# Altium schematic sheet Power.SchDoc
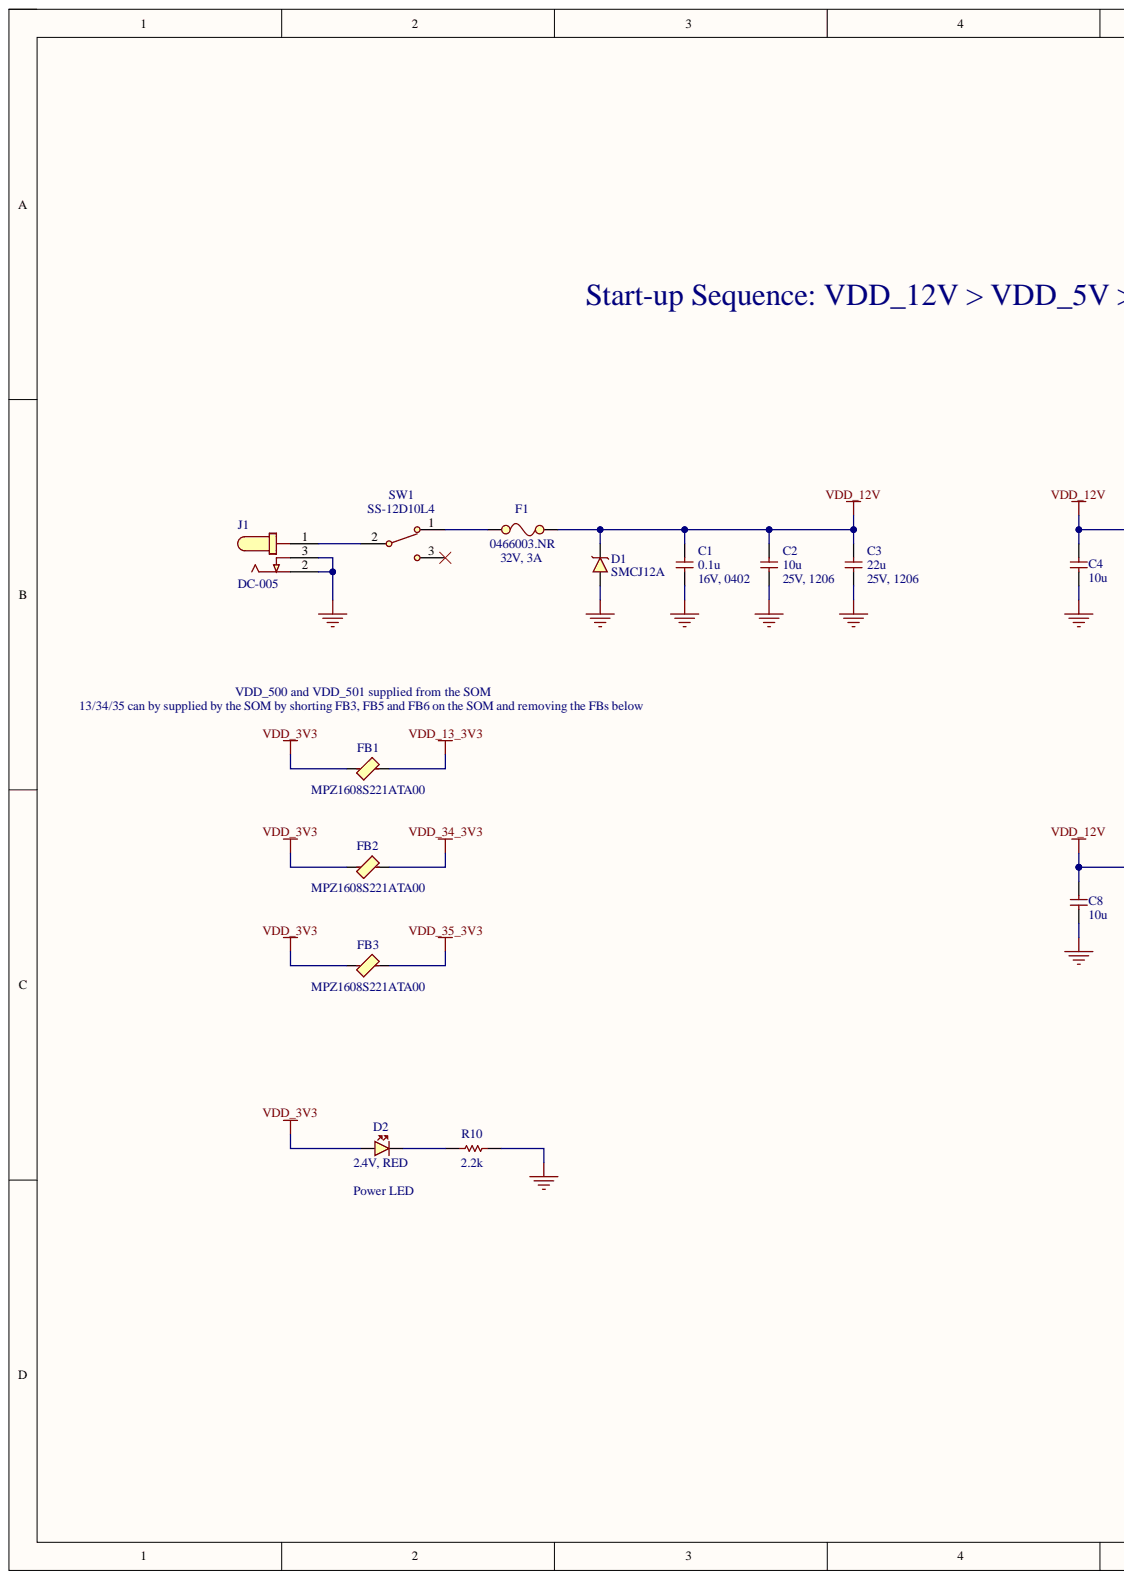
[diagram p0: Power.SchDoc, left]
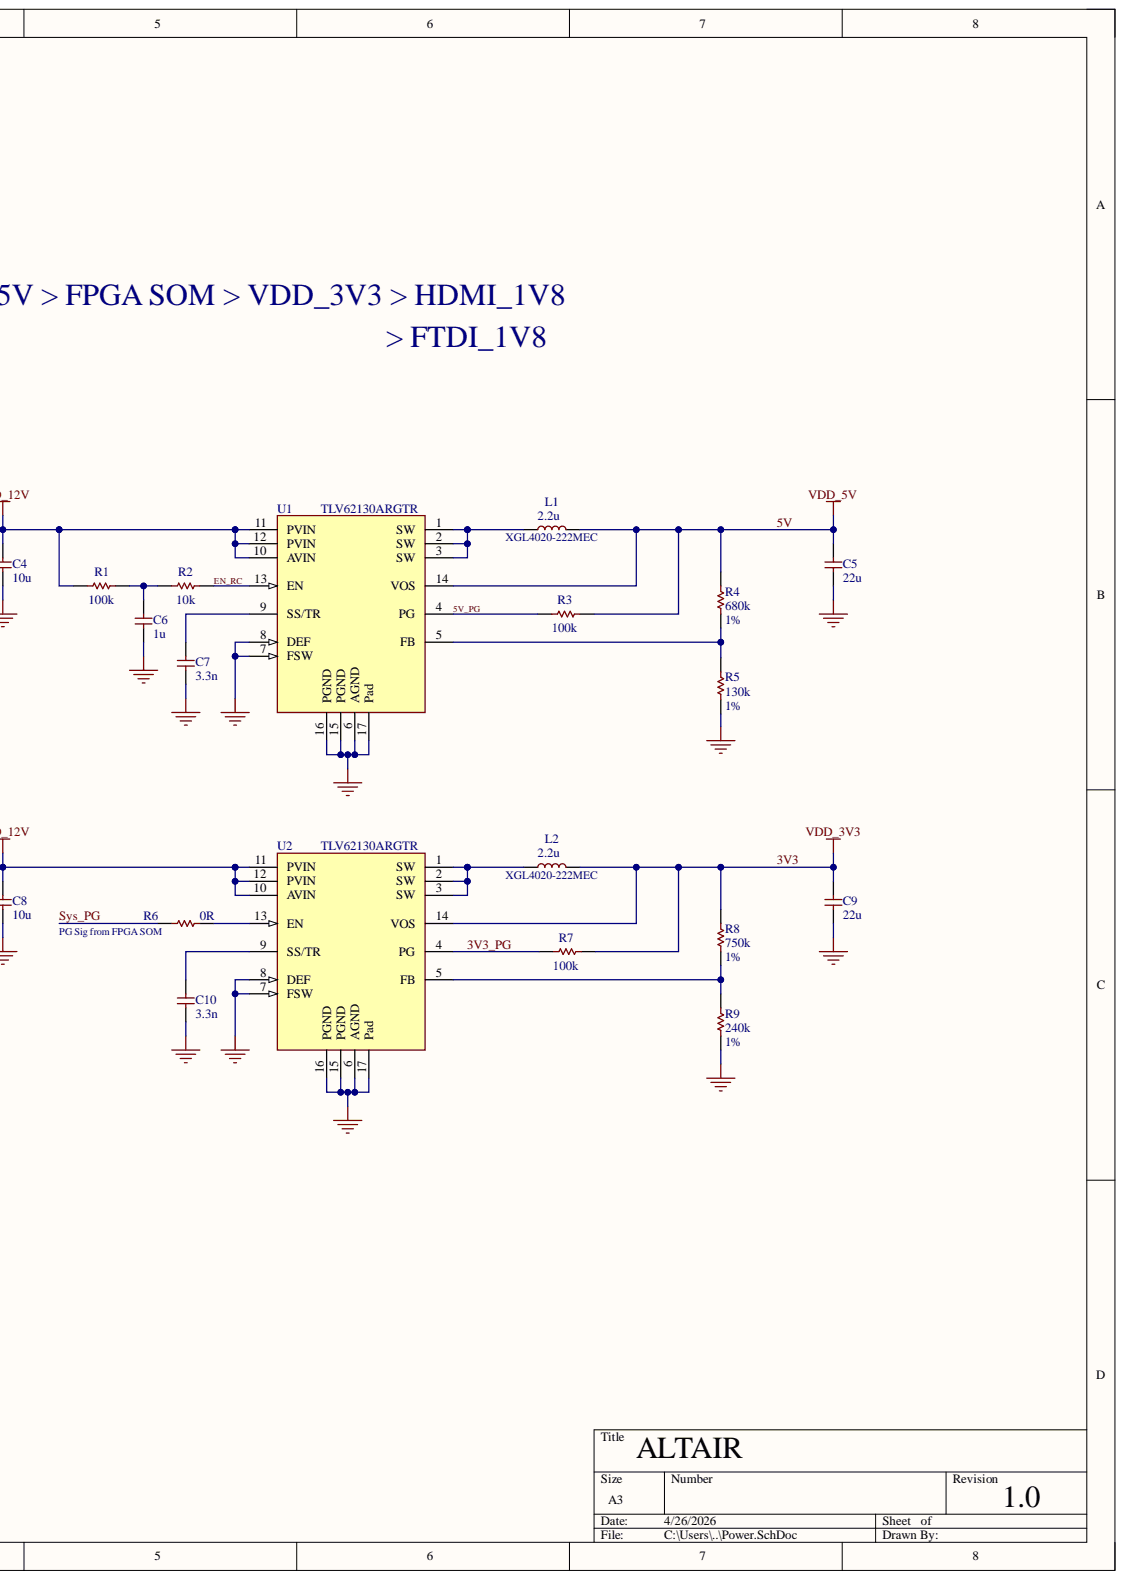
[diagram p1: Power.SchDoc, right]

## Parts
C1  0.1u  [CAP_0402]
C2  10u  [CAP_1206]
C3  22u  Footprint JLCPCB: 1206  Note/Misc: 25V, 1206  [CAP_1206]
C4  10u  [CAP_1206]
C5  22u  [CAP_1206]
C6  1u  [CAP_0402]
C7  3.3n  [CAP_0402]
C8  10u  [CAP_1206]
C9  22u  [CAP_1206]
C10  3.3n  [CAP_0402]
D1  SMCJ12A  [LFUS-DO-214AB-2_V]
D2  RED  Note/Misc: 2.4V, RED  [LED_0805_RED]
F1  0466003.NR  Note/Misc: 32V, 3A  [0466003.NR]
FB1  220  [BEADC1608X75N]  (value not drawn)
FB2  220  [BEADC1608X75N]  (value not drawn)
FB3  220  [BEADC1608X75N]  (value not drawn)
J1  DC-005  [DC-005-A200]
L1  2.2u  Note/Misc: XGL4020-222MEC  [XGL4020222MEC]
L2  2.2u  Note/Misc: XGL4020-222MEC  [XGL4020222MEC]
R1  100k  [RES_0402]
R2  10k  [RES_0402]
R3  100k  [RES_0402]
R4  680k  Note/Misc: 1%  [RES_0402]
R5  130k  Note/Misc: 1%  [RES_0402]
R6  0R  [RES_0402]
R7  100k  [RES_0402]
R8  750k  Note/Misc: 1%  [RES_0402]
R9  240k  Note/Misc: 1%  [RES_0402]
R10  2.2k  [RES_0402]
SW1  SS-12D10L4  [SS-12D10L5]
U1  TLV62130ARGTR  [FP-RGT0016C-MFG]
U2  TLV62130ARGTR  [FP-RGT0016C-MFG]

## Nets
GND: C1.1 C2.1 C3.1 C4.1 C5.1 C6.1 C7.1 C8.1 C9.1 C10.1 D1.2 J1.2 J1.3 R5.2 R9.2 R10.2 U1.6(AGND) U1.7(FSW) U1.8(DEF) U1.15(PGND) U1.16(PGND) U1.17(Pad) U2.6(AGND) U2.7(FSW) U2.8(DEF) U2.15(PGND) U2.16(PGND) U2.17(Pad)
VDD_12V: C1.2 C2.2 C3.2 C4.2 C8.2 D1.1 F1.2 R1.2 U1.10(AVIN) U1.11(PVIN) U1.12(PVIN) U2.10(AVIN) U2.11(PVIN) U2.12(PVIN)
VDD_13_3V3: FB1.2
VDD_34_3V3: FB2.2
VDD_35_3V3: FB3.2
3V3: C9.2 D2.A FB1.1 FB2.1 FB3.1 L2.2 R7.2 R8.2 U2.14(VOS)
3V3_PG: R7.1 U2.4(PG)
5V: C5.2 L1.2 R3.2 R4.2 U1.14(VOS)
5V_PG: R3.1 U1.4(PG)
EN_RC: R2.1 U1.13(EN)
Sys_PG: R6.1
NetC6_2: C6.2 R1.1 R2.2
NetC7_2: C7.2 U1.9(SS/TR)
NetC10_2: C10.2 U2.9(SS/TR)
NetD2_K: D2.K R10.1
NetF1_1: F1.1 SW1.1
NetJ1_1: J1.1 SW1.2
NetL1_1: L1.1 U1.1(SW) U1.2(SW) U1.3(SW)
NetL2_1: L2.1 U2.1(SW) U2.2(SW) U2.3(SW)
NetR4_1: R4.1 R5.1 U1.5(FB)
NetR6_2: R6.2 U2.13(EN)
NetR8_1: R8.1 R9.1 U2.5(FB)

Not connected: SW1 3

## Library data of the parts
C1 = CAP_0402: Capacitor SMD 0402; Part No: CL05B104KO5NNNC; Note/Misc: 16V, 0402; Component Kind: Standard; Footprint JLCPCB: 0402; JLCPCB Part #: C338112; Library Name: personalGenericLib.SchLib
C2 C4 C8 = CAP_1206: Capacitor SMD 1206; Part No: CL31A106KAHNNNE; Note/Misc: 25V, 1206; Component Kind: Standard; Footprint JLCPCB: 1206; JLCPCB Part #: C9807; Library Name: personalGenericLib.SchLib
C3 = CAP_1206: Capacitor SMD 1206; Part No: CL31A226KAHNNNE; Component Kind: Standard; JLCPCB Part #: C12891; Library Name: personalGenericLib.SchLib
C5 C9 = CAP_1206: Capacitor SMD 1206; Part No: CL31A226KAHNNNE; Note/Misc: 25V, 1206; Component Kind: Standard; Footprint JLCPCB: 1206; JLCPCB Part #: C12891; Library Name: personalGenericLib.SchLib
C6 = CAP_0402: Capacitor SMD 0402; Part No: CL05A105KP5NNNC; Note/Misc: 10V; Component Kind: Standard; Footprint JLCPCB: 0402; JLCPCB Part #: C14445; Library Name: personalGenericLib.SchLib
C7 C10 = CAP_0402: Capacitor SMD 0402; Part No: CC0402JRX7R8BB332; Component Kind: Standard; Footprint JLCPCB: 0402; JLCPCB Part #: C527004; Library Name: personalGenericLib.SchLib
D1 = SMCJ12A: Transient Voltage Suppression Diode, 12 V, -65 to 150 degC, 2-Pin DO-214AB (SMCJ), RoHS, Tape and Reel; Component Kind: Standard; Footprint JLCPCB: DO-214AB(SMCJ); JLCPCB Part #: C224038; Library Name: personalGenericLib.SchLib; Part No: SMCJ12A
D2 = LED_0805_RED: LED 0805 RED SMD; Part No: HL-PSC-2012S9AC; Component Kind: Standard; Footprint JLCPCB: 0805; JLCPCB Part #: C497952; Library Name: personalGenericLib.SchLib
F1 = 0466003.NR: FUSE MNT 3A 32VAC/VDC 1206; Component Kind: Standard; Footprint JLCPCB: 1206; JLCPCB Part #: C14165; Library Name: personalGenericLib.SchLib; Part No: 0466003.NR
FB1-FB3 = MPZ1608S221ATA00: Ferrite Bead; Component Kind: Standard; Footprint JLCPCB: 0603; JLCPCB Part #: C76815; Library Name: personalGenericLib.SchLib; Part No: MPZ1608S221ATA00
J1 = DC-005: DC Jack; Component Kind: Standard; Footprint JLCPCB: Plugin; JLCPCB Part #: C720557; Library Name: personalGenericLib.SchLib; Part No: DC-005
L1 L2 = IND_XGL4020-222MEC: Inductor SMD 2.2 uH; Component Kind: Standard; Footprint JLCPCB: 1616; JLCPCB Part #: C6012410; Library Name: personalGenericLib.SchLib; Part No: XGL4020-222MEC
R1 R3 R7 = RES_0402: Resistor SMD 0402; Part No: RC0402JR-07100KL; Component Kind: Standard; Footprint JLCPCB: 0402; JLCPCB Part #: C106234; Library Name: personalGenericLib.SchLib
R2 = RES_0402: Resistor SMD 0402; Part No: RC0402JR-0710KL; Component Kind: Standard; Footprint JLCPCB: 0402; JLCPCB Part #: C60489; Library Name: personalGenericLib.SchLib
R4 = RES_0402: Resistor SMD 0402; Part No: RC0402FR-07680KL; Component Kind: Standard; Footprint JLCPCB: 0402; JLCPCB Part #: C163456; Library Name: personalGenericLib.SchLib
R5 = RES_0402: Resistor SMD 0402; Part No: RC0402FR-07130KL; Component Kind: Standard; Footprint JLCPCB: 0402; JLCPCB Part #: C93946; Library Name: personalGenericLib.SchLib
R6 = RES_0402: Resistor SMD 0402; Part No: RC0402JR-070RL; Component Kind: Standard; Footprint JLCPCB: 0402; JLCPCB Part #: C60485; Library Name: personalGenericLib.SchLib
R8 = RES_0402: Resistor SMD 0402; Part No: RC0402FR-07750KL; Component Kind: Standard; Footprint JLCPCB: 0402; JLCPCB Part #: C137937; Library Name: personalGenericLib.SchLib
R9 = RES_0402: Resistor SMD 0402; Part No: RC0402FR-07240KL; Component Kind: Standard; Footprint JLCPCB: 0402; JLCPCB Part #: C138029; Library Name: personalGenericLib.SchLib
R10 = RES_0402: Resistor SMD 0402; Part No: RC0402JR-072K2L; Component Kind: Standard; Footprint JLCPCB: 0402; JLCPCB Part #: C163447; Library Name: personalGenericLib.SchLib
SW1 = SS-12D10L5: Slide Switch; Component Kind: Standard; Footprint JLCPCB: Plugin; JLCPCB Part #: C843364; Library Name: personalGenericLib.SchLib; Part No: SS-12D10L4
U1 U2 = TLV62130ARGTR: IC REG BUCK ADJUSTABLE 3A 16QFN; Component Kind: Standard; Footprint JLCPCB: VQFN-16-EP(3x3); JLCPCB Part #: C138520; Library Name: personalGenericLib.SchLib; Part No: TLV62130ARGTR
